# Altium schematic sheet 1. Power&Connectors.SchDoc("1. Power&Connectors")
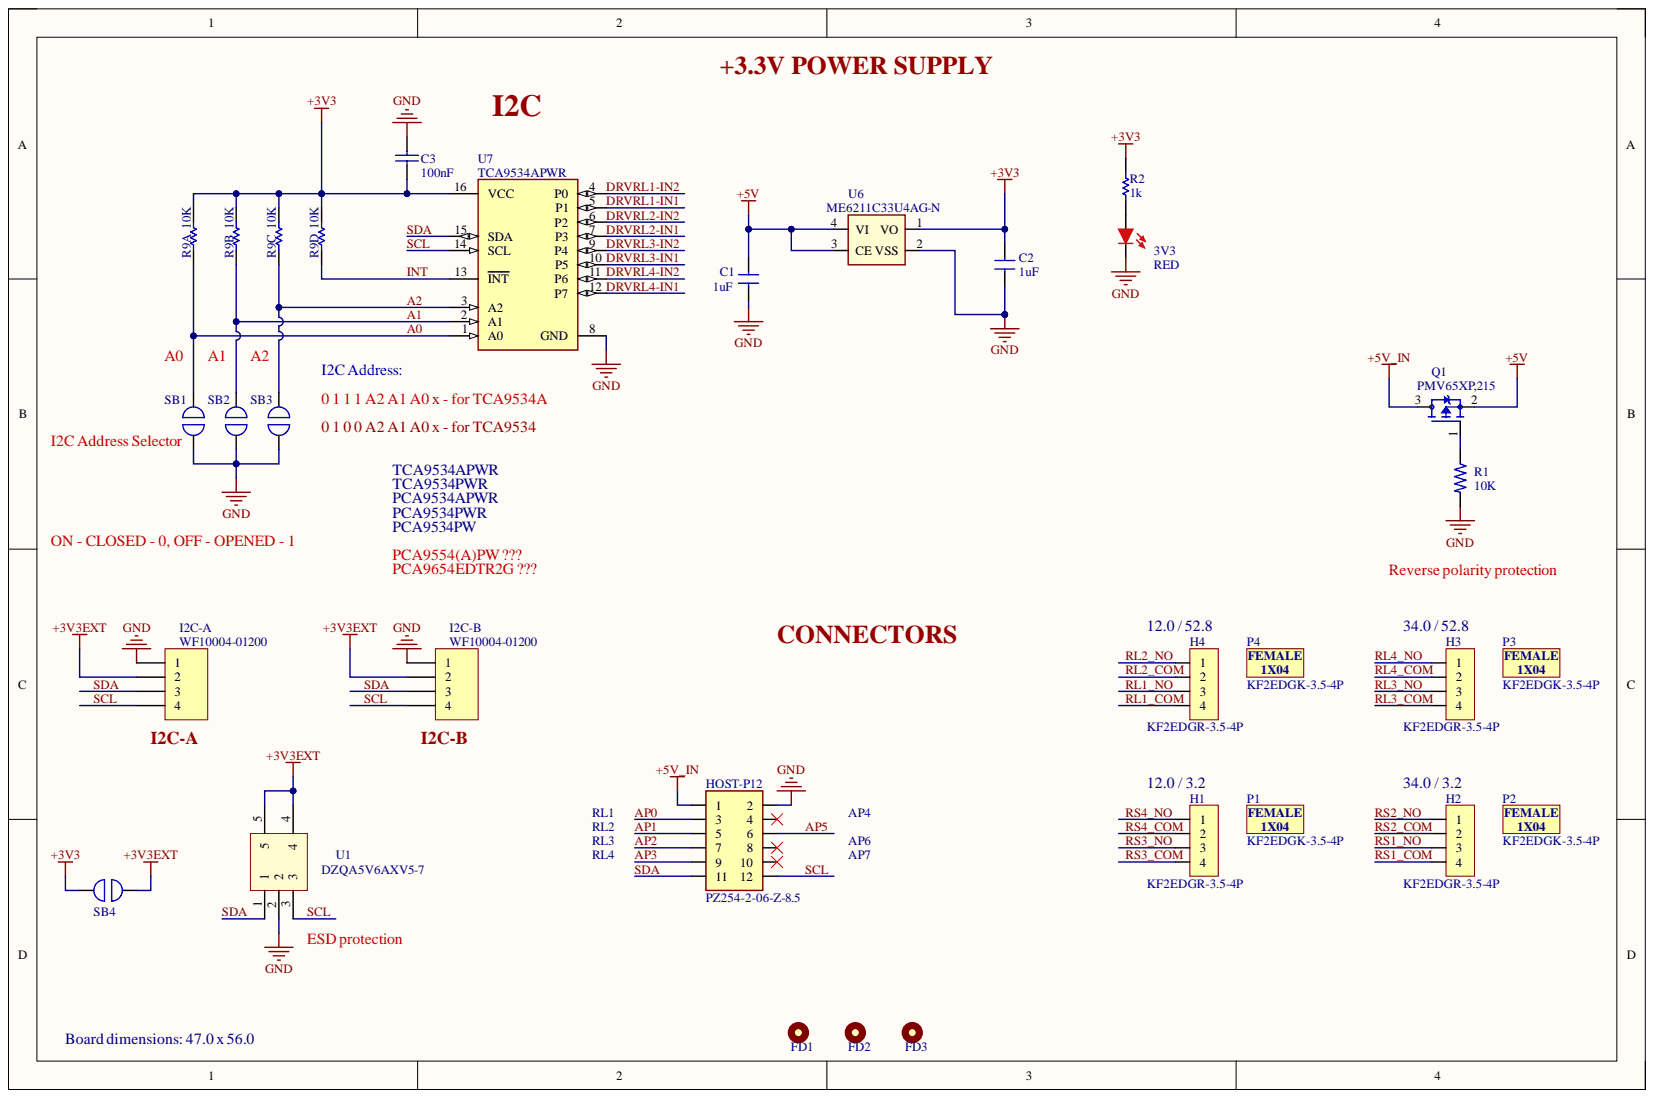
[diagram p0: 1. Power&Connectors.SchDoc]

## Parts
3V3  RED  [LED0603_RED]
C1  1uF  [CN-SMD-0402]
C2  1uF  [CN-SMD-0402]
C3  100nF  [CAPC1608X100N]
FD1  FIDUCIAL_MARK  [FIDUCIAL_MARK_1MM]  (value not drawn)  (no BOM)
FD2  FIDUCIAL_MARK  [FIDUCIAL_MARK_1MM]  (value not drawn)  (no BOM)
FD3  FIDUCIAL_MARK  [FIDUCIAL_MARK_1MM]  (value not drawn)  (no BOM)
H1  KF2EDGR-3.5-4P  [CON_1X04_3.5MM_MALE_RA]
H2  KF2EDGR-3.5-4P  [CON_1X04_3.5MM_MALE_RA]
H3  KF2EDGR-3.5-4P  [CON_1X04_3.5MM_MALE_RA]
H4  KF2EDGR-3.5-4P  [CON_1X04_3.5MM_MALE_RA]
HOST-P12  PZ254-2-06-Z-8.5  [PLD-12]
I2C-A  WF10004-01200  [SM04B-SRSS-TB(LF)(SN)]
I2C-B  WF10004-01200  [SM04B-SRSS-TB(LF)(SN)]
P1  KF2EDGK-3.5-4P  (mechanical)
P2  KF2EDGK-3.5-4P  (mechanical)
P3  KF2EDGK-3.5-4P  (mechanical)
P4  KF2EDGK-3.5-4P  (mechanical)
Q1  PMV65XP,215  [SOT95P230X110-3N]
R1  10K  [R0402]
R2  1k  [RESC1608X50N]
R9  10K  [CAC-50P200X100-8M_10K]  (parts A,B,C,D)
SB1  SOLDER_JUMPER_NO  [SOLDER_JUMPER_NO_2]  (value not drawn)  (no BOM)
SB2  SOLDER_JUMPER_NO  [SOLDER_JUMPER_NO_2]  (value not drawn)  (no BOM)
SB3  SOLDER_JUMPER_NO  [SOLDER_JUMPER_NO_2]  (value not drawn)  (no BOM)
SB4  SOLDER_JUMPER_NO  [SOLDER_JUMPER_NO_2]  (value not drawn)  (no BOM)
U1  DZQA5V6AXV5-7  [SOT50P160X60-5N]
U6  ME6211C33U4AG-N  [FBP4L_L1.0-W1.0-P0.65-BL-EP]
U7  TCA9534APWR  [PW0016A TSSOP16]

## Nets
+3V3: C2.2 C3.1 R2.2 R9.5 R9.6 R9.7 R9.8 SB4.2 U6.1 U7.16
+3V3EXT: I2C-A.2 I2C-B.2 SB4.1 U1.4 U1.5
+5V: C1.2 Q1.2 U6.3 U6.4
+5V_IN: HOST-P12.1 Q1.3
GND: 3V3.K C1.1 C2.1 C3.2 HOST-P12.2 I2C-A.1 I2C-B.1 R1.1 SB1.1 SB2.1 SB3.1 U1.2 U6.2 U7.8
A0: R9.1 SB1.2 U7.1
A1: R9.2 SB2.2 U7.2
A2: R9.3 SB3.2 U7.3
AP0: HOST-P12.3
AP1: HOST-P12.5
AP2: HOST-P12.7
AP3: HOST-P12.9
AP5: HOST-P12.6
DRVRL1-IN1: U7.5
DRVRL1-IN2: U7.4
DRVRL2-IN1: U7.7
DRVRL2-IN2: U7.6
DRVRL3-IN1: U7.10
DRVRL3-IN2: U7.9
DRVRL4-IN1: U7.12
DRVRL4-IN2: U7.11
INT: R9.4 U7.13
RL1_COM: H4.4
RL1_NO: H4.3
RL2_COM: H4.2
RL2_NO: H4.1
RL3_COM: H3.4
RL3_NO: H3.3
RL4_COM: H3.2
RL4_NO: H3.1
RS1_COM: H2.4
RS1_NO: H2.3
RS2_COM: H2.2
RS2_NO: H2.1
RS3_COM: H1.4
RS3_NO: H1.3
RS4_COM: H1.2
RS4_NO: H1.1
SCL: HOST-P12.12 I2C-A.4 I2C-B.4 U1.3 U7.14
SDA: HOST-P12.11 I2C-A.3 I2C-B.3 U1.1 U7.15
Net3V3_A: 3V3.A R2.1
NetQ1_1: Q1.1 R1.2

Not connected: FD1 1; FD2 1; FD3 1; HOST-P12 4 8 10

## Library data of the parts
3V3 = LED0603_RED: LED RED CLEAR CHIP SMD 0603; Manufacturer: HONGLITRONIC(Hongli Zhihui (HONGLITRONIC)); Manufacturer Part Number: HL-PST-1608U38FC; Dominant Wavelength: 631nm; Forward Current: 30mA; Forward Voltage: 2.4V; Lens Color: Colorless; Lens Style: Diffused,Colorless,Clear,Rectangular; Luminous Intensity: 54mcd; Number of Elements: 1; Power Dissipation: 75mW; Reverse Voltage: 5V; Test Current: 20mA; Viewing Angle: 130°; Wavelength: 639nm; Case Code (Imperial): 0603; Case Code (Metric): 1608; Case/Package: 0603; Category: LEDs; Color: Red; Component Kind: Standard; ComponentLink1Description: https://datasheet.ciiva.com/19482/1260381-19482354.pdf?src-supplier=Jameco; ComponentLink1URL: https://datasheet.ciiva.com/19482/1260381-19482354.pdf?src-supplier=Jameco; ComponentLink2Description: https://datasheet.ciiva.com/11774/0900766b80dc02a9-11774043.pdf?src-supplier=RSComponents; ComponentLink2URL: https://datasheet.ciiva.com/11774/0900766b80dc02a9-11774043.pdf?src-supplier=RSComponents; ComponentLink3Description: https://datasheet.ciiva.com/12403/100-ltst-c190krkt-59805-12403895.pdf?src-supplier=Mouser; ComponentLink3URL: https://datasheet.ciiva.com/12403/100-ltst-c190krkt-59805-12403895.pdf?src-supplier=Mouser; ComponentLink4Description: https://datasheet.ciiva.com/16427/ltst-c190krkt-16427674.pdf?src-supplier=Future+Electronics; ComponentLink4URL: https://datasheet.ciiva.com/16427/ltst-c190krkt-16427674.pdf?src-supplier=Future+Electronics; ComponentLink5Description: https://datasheet.ciiva.com/15117/getdatasheetpartid-57395-15117240.pdf?src-supplier=Verical; ComponentLink5URL: https://datasheet.ciiva.com/15117/getdatasheetpartid-57395-15117240.pdf?src-supplier=Verical; ComponentLink6Description: https://datasheet.ciiva.com/2109/s-110-ltst-c190krkt-2109992.pdf?src-supplier=Digi-Key; ComponentLink6URL: https://datasheet.ciiva.com/2109/s-110-ltst-c190krkt-2109992.pdf?src-supplier=Digi-Key; ComponentLink7Description: https://datasheet.ciiva.com/2109/s-110-ltst-c190krkt-2109991.pdf?src-supplier=Digi-Key; ComponentLink7URL: https://datasheet.ciiva.com/2109/s-110-ltst-c190krkt-2109991.pdf?src-supplier=Digi-Key; ComponentLink8Description: https://datasheet.ciiva.com/2109/s-110-ltst-c190krkt-2109993.pdf?src-supplier=Digi-Key; ComponentLink8URL: https://datasheet.ciiva.com/2109/s-110-ltst-c190krkt-2109993.pdf?src-supplier=Digi-Key; ComponentLink9Description: https://datasheet.ciiva.com/26937/getdatasheetpartid-631582-26937958.pdf?src-supplier=Verical; ComponentLink9URL: https://datasheet.ciiva.com/26937/getdatasheetpartid-631582-26937958.pdf?src-supplier=Verical; Depth: 0.8mm; Height: 0.8mm; Illumination Color: Red; Lead Free: Lead Free; Length: 1.6mm; Lens Transparency: Clear; Library Name: Schematic_Lib.SchLib; Max Operating Temperature: 85°C; Min Operating Temperature: -55°C; Mount: Surface Mount; Number of LEDs: 1; Number of Pins: 2; Package Quantity: 1; Packaging: Tape and Reel; Power Rating: 75mW; Pricing 1: 1=0.24000, 10=0.13200, 100=0.06110, 500=0.05640, 1000=0.04418, 2000=0.04324 (USD); Radiation Hardening: No; RoHS: Yes; RoHS Compliant: Yes; Stock 1: 843370; Supplier 1: LCSC; Supplier Part Number 1: C497928; Width: 0.8mm
C1 C2 = C0402_1uF: Component Kind: Standard; Description: C0402_1uF; Library Name: Bokra.SCHLIB
C3 = Cap: CAP CER 0.1UF 50V X7R 0603; Component Kind: Standard; LatestRevisionDate: 17-Jul-2002; LatestRevisionNote: Re-released for DXP Platform.; Library Name: Miscellaneous Devices.IntLib; PackageDescription: Radial Cap, Thru-Hole; 2 Leads; 0.3 in Pin Spacing; PackageReference: RAD-0.3; Published: 8-Jun-2000; Signal Integrity: Cap; Simulation: CAP; Value: 100nF; Publisher: Altium Limited
FD1-FD3 = FIDUCIAL_MARK: Checked_By: Dodig; Drawn_By: Puskar; BL Part Number: N/A; DateModified: 17-06-2013; Description: FIDUCIAL_MARK; Library Name: Schematic_Lib.SchLib; Manufacturer: N/A; Manufacturer Part Number: N/A
H1-H4 = CON_1X04_3.5MM_MALE_RA: 300V 8A 4 Bend -40℃~+105℃ 1 3.5mm Green Board Edge/Receptacle-Close Push-Pull,P=3.5mm Pluggable System Terminal Block ROHS; Manufacturer: Cixi Kefa Elec; Component Kind: Standard; Library Name: Schematic_Lib.SchLib; Manufacturer Part Number: KF2EDGR-3.5-4P; Supplier 1: LCSC; Supplier Part Number 1: C441173
HOST-P12 = PLD-12: 2.54mm Header V/T-type, 2x06 Pin; Component Kind: Standard; Value: PZ254-2-06-Z-8.5; Library Name: IoTextra_Input_2-03.SCHLIB
I2C-A = Header 4: Header, 4-Pin; Manufacturer: SHENZHEN ATOM TECH; Component Kind: Standard; Library Name: Miscellaneous Connectors.IntLib; Manufacturer Part Number: WF10004-01200; Signal Integrity: Connector; Supplier 1: LCSC; Supplier Part Number 1: C479990
I2C-B = Header 4: 1x4P 1 1mm Male pin 4 -25℃~+85℃ 500mA Surface Mount SMD,P=1mm Wire To Board / Wire To Wire Connector ROHS; Manufacturer: SHENZHEN ATOM TECH; Component Kind: Standard; Library Name: Miscellaneous Connectors.IntLib; Manufacturer Part Number: WF10004-01200; Signal Integrity: Connector; Supplier 1: LCSC; Supplier Part Number 1: C479990
P1-P4 = CON_1X04_3.5MM_FEMALE_RA: 250V 7A 4 1.5 1 16~28 3.5mm Green Plug P=3.5mm Pluggable System Terminal Block ROHS; Manufacturer: Cixi Kefa Elec; Library Name: Schematic_Lib.SchLib; Manufacturer Part Number: KF2EDGK-3.5-4P; Supplier 1: LCSC; Supplier Part Number 1: C440849
Q1 = PMV65XP,215: MOSFET P-CH 20V 2.8A TO236AB; Manufacturer: Nexperia USA; Component Kind: Standard; Library Name: Symbol.SchLib; Manufacturer Part Number: PMV65XP,215; Supplier 1: LCSC; Supplier Part Number 1: C75561
R1 = R0402_10K: MFR: YAGEO; Component Kind: Standard; Description: R0402_10K; Value: 10K; Library Name: Bokra.SCHLIB
R2 = Res1: Resistor; Component Kind: Standard; LatestRevisionDate: 17-Jul-2002; LatestRevisionNote: Re-released for DXP Platform.; Library Name: Miscellaneous Devices.IntLib; PackageDescription: Axial Device, Thru-Hole; 2 Leads; 0.3 in Pin Spacing; PackageReference: AXIAL-0.3; Published: 8-Jun-2000; Signal Integrity: Res; Simulation: RESISTOR; Value: 1k; Publisher: Altium Limited
R9 = EXB-28V103JX: RES ARRAY 4 RES 10K OHM 0804; Component Kind: Standard; LCSC #: C178757; Value: EXB-28V103JX; Library Name: Bokra.SCHLIB
SB1-SB4 = SOLDER_JUMPER_NO: Solder Jumper; Library Name: IoTextra_Input_2-03.SCHLIB
U1 = NZQA5V6XV5T1G: 5.3V 13V 4 3V SOT-553 ESD Protection Devices ROHS; Manufacturer: Diodes Incorporated; Component Kind: Standard; Manufacturer Part Number: DZQA5V6AXV5-7; Supplier 1: LCSC; Supplier Part Number 1: C1973056; Library Name: IoTbase B+ 3-01.SCHLIB
U6 = ME6211C33U4AG-N: High Speed LDO Regulators, High PSRR, Low noise; Component Kind: Standard; LCSC #: C428904; Value: ME6211C33U4AG-N; Library Name: Bokra.SCHLIB
U7 = TCA9534APWR: IC I/O EXPANDER I2C 8B 16TSSOP; Manufacturer: Texas Instruments; Frequency: 400kHz; Interface: I2C,SMBus; Max Junction Temp: 100°C; Max Supply Voltage: 5.5V; Min Supply Voltage: 1.65V; Nominal Supply Current: 5-22uA; Number Of I/Os: 8; Standoff Height: 0.05mm; Ambient Temperature Range High: +85°C; Ambient Temperature Range Low: -40°C; Automotive: No; Category: IC; Component Kind: Standard; ComponentLink2Description: Datasheet; ComponentLink2URL: http://www.ti.com/lit/ds/symlink/tca9534a.pdf; Device Class L1: Integrated Circuits (ICs); Device Class L2: Interface ICs; Device Class L3: I/O Expanders; Digikey Description: IC I/O EXPANDER I2C 8B 16TSSOP; Height: 1.2mm; Lead Free: Yes; Manufacturer Part Number: TCA9534APWR; Octopart: https://octopart.com/tca9534apwr-texas+instruments-50333529; Package: PW0016A; RoHS: TRUE; Temperature: -40°C to +85°C; Temperature Range High: +85°C; Temperature Range Low: -40°C; Library Name: IoTextra_Input_2-03.SCHLIB
